# Altium schematic sheet power.SchDoc(power)
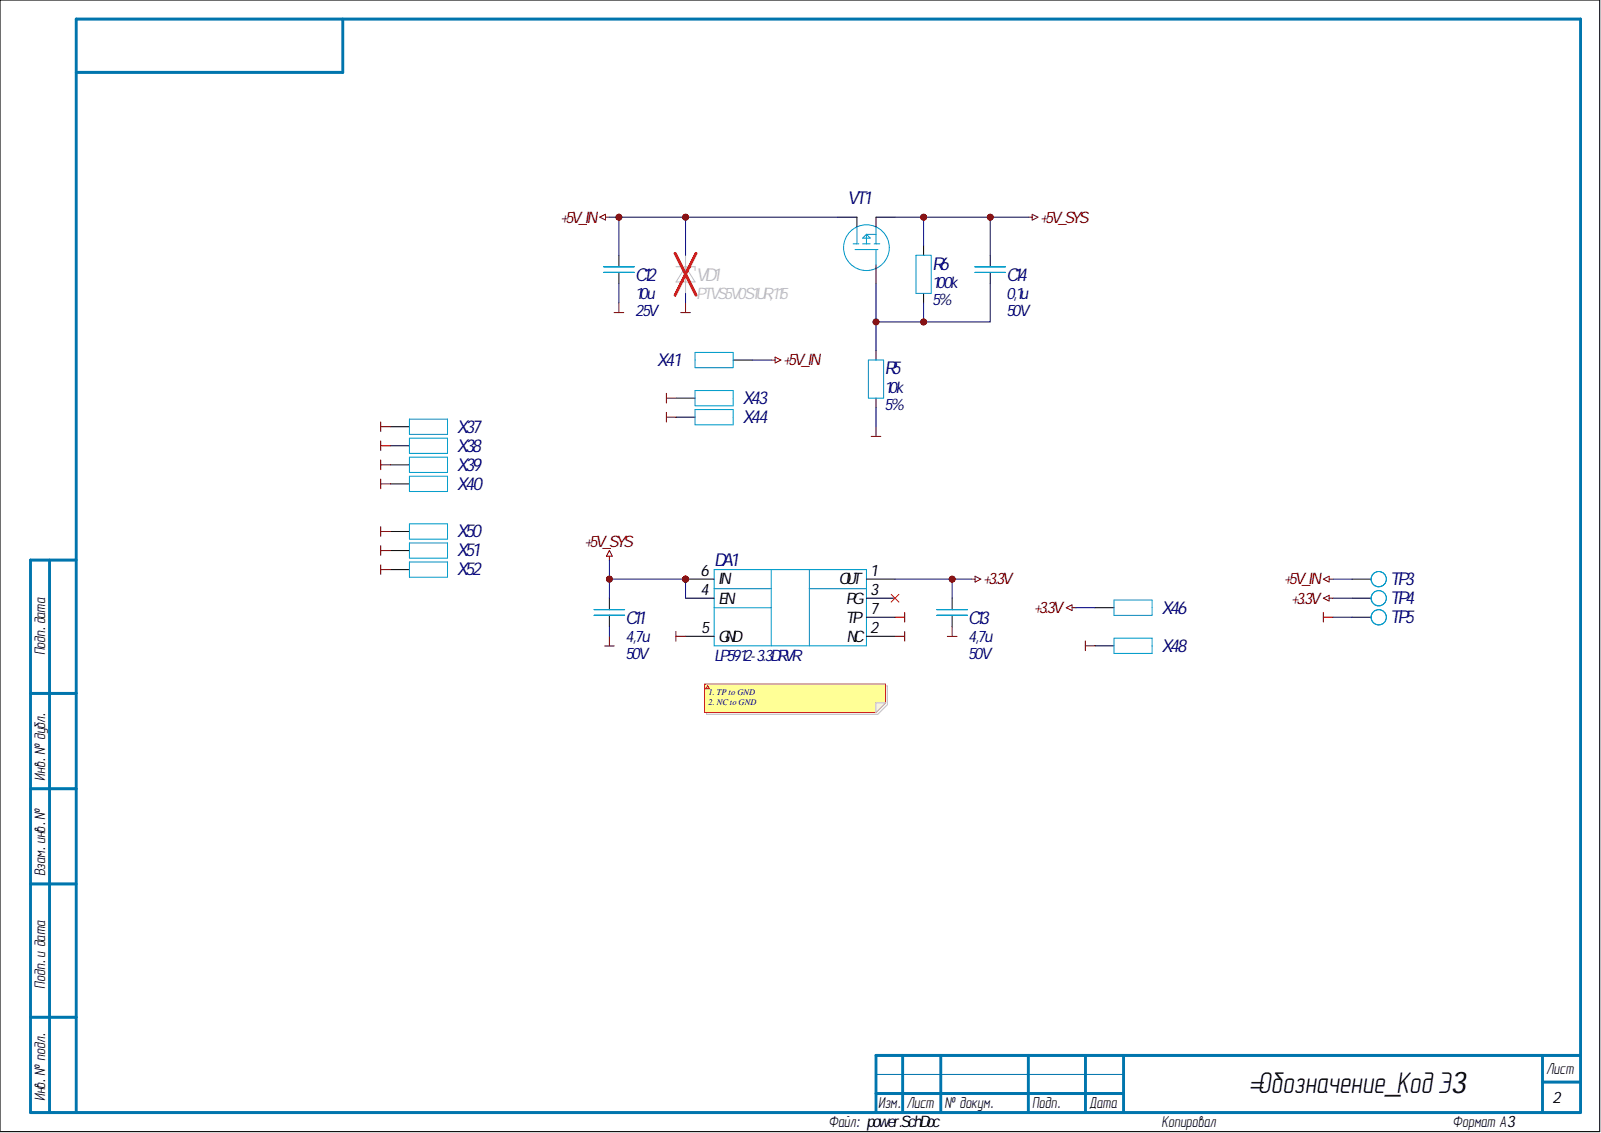
[diagram p0: power.SchDoc]

## Parts
C11  value 4,7u  Voltage: 50V  [CAPC2012X13N]  (hidden comment: CC0805KKX7R9BB475)
C12  value 10u  Voltage: 25V  [CAPC2012X13N]  (hidden comment: GRM21BZ71E106KE15L)
C13  value 4,7u  Voltage: 50V  [CAPC2012X13N]  (hidden comment: CC0805KKX7R9BB475)
C14  value 0,1u  Voltage: 50V  [CAPC1005X06N]  (hidden comment: CC0402KRX7R9BB104)
DA1  LP5912-3.3DRVR  [SON65P200X80_HS-7N]
R5  value 10k  Accuracy: 5%  [RESC1005X03N]  (hidden comment: RC0402JR-0710KL)
R6  value 100k  Accuracy: 5%  [RESC1005X03N]  (hidden comment: RC0402JR-07100KL)
TP3  Test Point 1mm  [Test Point 1mm]  (value not drawn)  (no BOM)
TP4  Test Point 1mm  [Test Point 1mm]  (value not drawn)  (no BOM)
TP5  Test Point 1mm  [Test Point 1mm]  (value not drawn)  (no BOM)
VD1  PTVS5V0S1UR,115  [SODFL350X110-2N]
VT1  DMP1245UFCL-7  [X1-DFN1616-6 (TYPE E)]  (value not drawn)
X37  (no value)  [Socket_th_06_10]
X38  (no value)  [Socket_th_06_10]
X39  (no value)  [Socket_th_06_10]
X40  (no value)  [Socket_th_06_10]
X41  (no value)  [Socket_th_06_10]
X43  (no value)  [Socket_th_06_10]
X44  (no value)  [Socket_th_06_10]
X46  (no value)  [Socket_th_06_10]
X48  (no value)  [Socket_th_06_10]
X50  (no value)  [Socket_th_06_10]
X51  (no value)  [Socket_th_06_10]
X52  (no value)  [Socket_th_06_10]

## Nets
+3.3V: C13.2 DA1.1(OUT) TP4.1 X46.0
+5V_IN: C12.2 TP3.1 VD1.1 VT1.D X41.0
+5V_SYS: C11.2 C14.2 DA1.4(EN) DA1.6(IN) R6.1 VT1.S
GND: C11.1 C12.1 C13.1 DA1.2(NC) DA1.5(GND) DA1.7(TP) R5.2 TP5.1 VD1.2 X37.0 X38.0 X39.0 X40.0 X43.0 X44.0 X48.0 X50.0 X51.0 X52.0
NetC14_1: C14.1 R5.1 R6.2 VT1.G

Not connected: DA1 3(PG)

## Library data of the parts
C11 C13 = CC0805KKX7R9BB475: C_0805 X7R 50V 4.7u; Manufacturer: YAGEO; Database Table Name: 0805$; Tolerance: 10%; Component Kind: Standard; Library Name: Capacitors.DbLib; Mount: SMD; Package: 0805; Part Number: CC0805KKX7R9BB475; TCE: X7R
C12 = GRM21BZ71E106KE15L: C_0805 X7R 25V 10u; Manufacturer: MURATA; Database Table Name: 0805$; Tolerance: 10%; Component Kind: Standard; Library Name: Capacitors.DbLib; Mount: SMD; Package: 0805; Part Number: GRM21BZ71E106KE15L; TCE: X7R
C14 = CC0402KRX7R9BB104: C_0402 X7R 50V 0.1u; Manufacturer: YAGEO; Database Table Name: 0402$; Tolerance: 10%; Component Kind: Standard; Library Name: Capacitors.DbLib; Mount: SMD; Package: 0402; Part Number: CC0402KRX7R9BB104; TCE: X7R
DA1 = LP5912-3.3DRVR: LP5912 500-mA Low-Noise, Low-IQ LDO; Manufacturer: TI; Database Table Name: Power Supply$; Component Kind: Standard; Library Name: ICs.DbLib; Mount: SMD; Part Number: LP5912-3.3DRVR
R5 = RC0402JR-0710KL: R_0402 5% 10k; Manufacturer: YAGEO; Database Table Name: 0402$; Power: 0,0625W; Component Kind: Standard; Library Name: Resistors.DbLib; Package: 0402; Part Number: RC0402JR-0710KL
R6 = RC0402JR-07100KL: R_0402 5% 100k; Manufacturer: YAGEO; Database Table Name: 0402$; Power: 0,0625W; Component Kind: Standard; Library Name: Resistors.DbLib; Package: 0402; Part Number: RC0402JR-07100KL
TP3-TP5 = Test Point 1mm: Debug test point. No solder.; Manufacturer: None; Database Table Name: Tst Points$; ClassName: TestPoint; Library Name: Connectors.DbLib; Part Number: Test Point 1mm
VD1 = PTVS5V0S1UR,115: 400 W Transient Voltage Suppressor; Manufacturer: NEXPERIA; Database Table Name: TVS$; Type: TVS; Component Kind: Standard; Library Name: Diodes.DbLib; Package: SOD123W; Part Number: PTVS5V0S1UR,115
VT1 = DMP1245UFCL-7: 12V P-CHANNEL ENHANCEMENT MODE MOSFET; Manufacturer: DIODES; Channel: P; Database Table Name: PMOS$; Id: -6.6A; Rd: 29m; VDSS: -12V; VGS: +-8V; Component Kind: Standard; Library Name: Transistors.DbLib; Package: X1-DFN1616-6; Part Number: DMP1245UFCL-7
X37-X41 X43 X44 X46 X48 X50-X52 = Socket_th_06_10: Database Table Name: Sockets$; Component Kind: Standard; Library Name: Connectors.DbLib; Part Number: Socket_th_06_10
